# Altium schematic sheet MCU.SchDoc
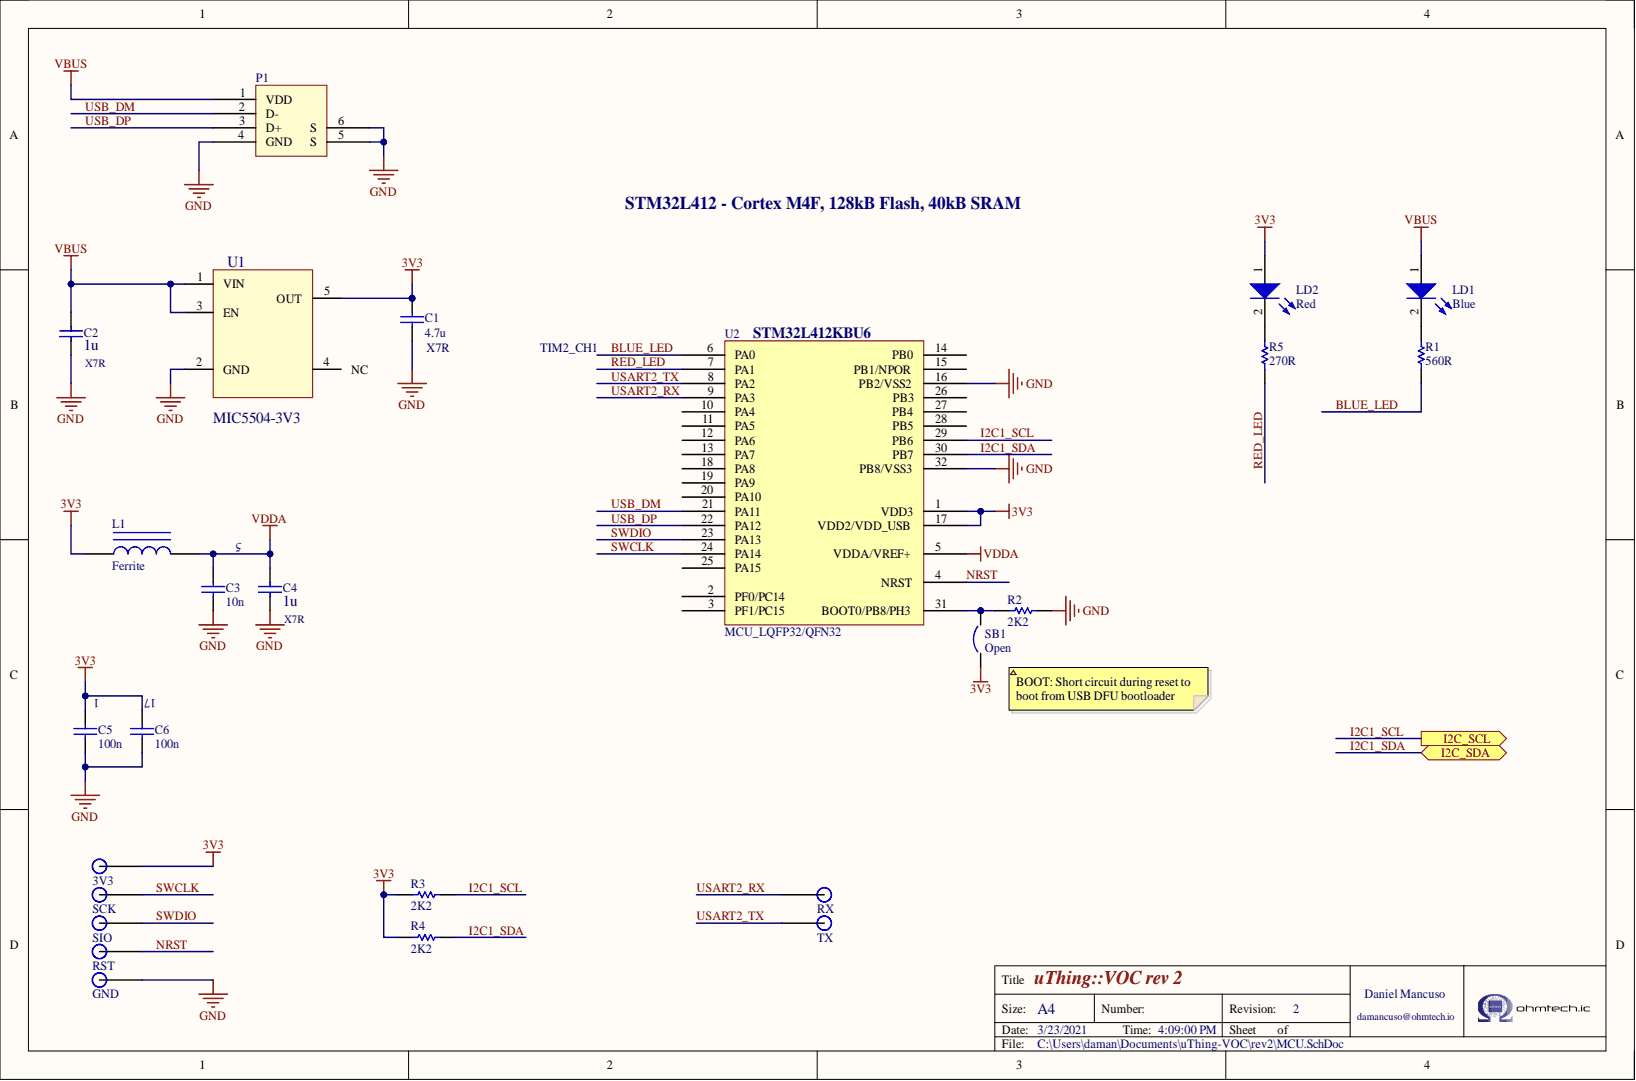
[diagram p0: MCU.SchDoc]

## Parts
3V3  Testpoint  [Testpoint]  (value not drawn)  (no BOM)
C1  X7R  value 4.7u  [CAP 0603/1608]
C2  X7R  value 1u  [CAP 0603/1608]
C3  value 10n  [CAP 0603/1608]  (hidden comment: Cap0603)
C4  X7R  value 1u  [CAP 0603/1608]
C5  value 100n  [CAP 0603/1608]  (hidden comment: Cap0603)
C6  value 100n  [CAP 0603/1608]  (hidden comment: Cap0603)
GND  Testpoint  [Testpoint]  (value not drawn)  (no BOM)
L1  Ferrite  [IND0603]
LD1  Blue  [LED0603]
LD2  Red  [LED0603]
P1  USB-A-Plug  [USB-A-plug]  (value not drawn)
R1  value 560R  [RES 0603/1608]  (hidden comment: Res0603, 0.1W)
R2  value 2K2  [RES 0603/1608]  (hidden comment: Res0603, 0.1W)
R3  value 2K2  [RES 0603/1608]  (hidden comment: Res0603, 0.1W)
R4  value 2K2  [RES 0603/1608]  (hidden comment: Res0603, 0.1W)
R5  value 270R  [RES 0603/1608]  (hidden comment: Res0603, 0.1W)
RST  Testpoint  [Testpoint]  (value not drawn)  (no BOM)
RX  Testpoint  [Testpoint]  (value not drawn)  (no BOM)
SB1  Open  [SB_0603]  (no BOM)
SCK  Testpoint  [Testpoint]  (value not drawn)  (no BOM)
SIO  Testpoint  [Testpoint]  (value not drawn)  (no BOM)
TX  Testpoint  [Testpoint]  (value not drawn)  (no BOM)
U1  MIC5504-3V3  [SOT-23-5]
U2  MCU_LQFP32/QFN32  [QFN32_0R5_5.3x5.3]

## Ports
I2C_SCL, I2C_SDA

## Nets
3V3: 3V3.1 C1.2 C5.2 C6.2 L1.1 LD2.1 R3.1 R4.1 SB1.1 U1.5(OUT) U2.1(VDD3) U2.17(VDD2/VDD_USB)
GND: C1.1 C2.1 C3.1 C4.1 C5.1 C6.1 GND.1 P1.4(GND) P1.5(S) P1.6(S) R2.2 U1.2(GND) U2.16(PB2/VSS2) U2.32(PB8/VSS3)
VBUS: C2.2 LD1.1 P1.1(VDD) U1.1(VIN) U1.3(EN)
VDDA: C3.2 C4.2 L1.2 U2.5(VDDA/VREF+)
BLUE_LED: R1.1 U2.6(PA0)
I2C1_SCL: R3.2 U2.29(PB6) ; port I2C_SCL
I2C1_SDA: R4.2 U2.30(PB7) ; port I2C_SDA
NRST: RST.1 U2.4(NRST)
RED_LED: R5.1 U2.7(PA1)
SWCLK: SCK.1 U2.24(PA14)
SWDIO: SIO.1 U2.23(PA13)
USART2_RX: RX.1 U2.9(PA3)
USART2_TX: TX.1 U2.8(PA2)
USB_DM: P1.2(D-) U2.21(PA11)
USB_DP: P1.3(D+) U2.22(PA12)
NetLD1_2: LD1.2 R1.2
NetLD2_2: LD2.2 R5.2
NetR2_1: R2.1 SB1.2 U2.31(BOOT0/PB8/PH3)

Not connected: U1 4; U2 2(PF0/PC14) 3(PF1/PC15) 10(PA4) 11(PA5) 12(PA6) 13(PA7) 14(PB0) 15(PB1/NPOR) 18(PA8) 19(PA9) 20(PA10) 25(PA15) 26(PB3) 27(PB4) 28(PB5)

## Library data of the parts
C1 = CAP_0603: 4.7u 0603; Component Kind: Standard; Library Name: Passives.IntLib; Supplier 1: Digi-Key; Supplier Part Number 1: 1276-2087-1-ND
C2-C6 = CAP_0603: -55-125C, 1608, 10V, X7R, ±10%; Component Kind: Standard; Library Name: Passives.IntLib; Supplier 1: Digi-Key
  C2 C4: Supplier Part Number 1: 1276-6524-1-ND
  C3: Supplier Part Number 1: 1276-1921-1-ND
  C5 C6: Supplier Part Number 1: 1276-1005-1-ND
L1 = MMZ1608Y152BTD25: Ferrite Bead, 0603,1.5Kohm@100MHz, 300mA-max; Component Kind: Standard; LatestRevisionDate: 17-Jul-2002; LatestRevisionNote: Re-released for DXP Platform.; Library Name: Passives.IntLib; PackageDescription: Axial Device, Thru-Hole; 2 Leads; 0.9 in Pin Spacing; PackageReference: AXIAL-0.9; Published: 24-Mar-1999; Supplier 1: Digi-Key; Supplier Part Number 1: 445-172846-1-ND; Publisher: Altium Limited
LD1 = LED_BLUE: Blue LED 0604; Component Kind: Standard; Library Name: LEDs.IntLib; Revision: July-2002: Re-released for DXP Platform.; Supplier 1: Digi-Key; Supplier Part Number 1: 1080-1562-1-ND
LD2 = LED_RED: Typical RED, GREEN, YELLOW, AMBER GaAs LED; Component Kind: Standard; Library Name: LEDs.IntLib; Published: 8-Jun-2000; Revision: July-2002: Re-released for DXP Platform.; Supplier 1: Digi-Key; Supplier Part Number 1: 160-1447-1-ND; Publisher: Altium Limited
P1 = USB-A-Plug: Conn USB RCP 4 POS 2.5mm Solder RA Edge Mount 4 Terminal 1 Port; Component Kind: Standard; Library Name: Connectors.SchLib; Supplier 1: Digi-Key; Supplier Part Number 1: 102-3996-ND
R1-R5 = RES_0603: 0.10W, -55-155C, 1005, 50V, ±1%; Component Kind: Standard; Library Name: Passives.IntLib; Supplier 1: Digi-Key
  R1: Supplier Part Number 1: RMCF0603JT560RCT-ND
  R2-R4: Supplier Part Number 1: RMCF0603JT2K20CT-ND
  R5: Supplier Part Number 1: RMCF0603JT270RCT-ND
SB1 = Circuit Breaker: Description: Circuit Breaker; Library Name: Mechanical.IntLib; Published: 24-Mar-1999; Revision: July-2002: Re-released for DXP Platform.; Publisher: Altium Limited
U1 = MIC5504-3V3: Linear Voltage Regulator IC Positive Fixed 1 Output 3.3V 300mA SOT-23-5; MF: Microchip; Component Kind: Standard; Library Name: Regulators.IntLib; Package: SOT23-5; Price: 0.1; Supplier 1: Digi-Key; Supplier Part Number 1: 576-4764-1-ND
U2 = MCU_LQFP32: Component Kind: Standard; Library Name: MB1180.SCHLIB
3V3 GND RST RX SCK SIO TX = Testpoint: Library Name: Mechanical.IntLib
